# Altium schematic sheet ADDITIONAL.SchDoc
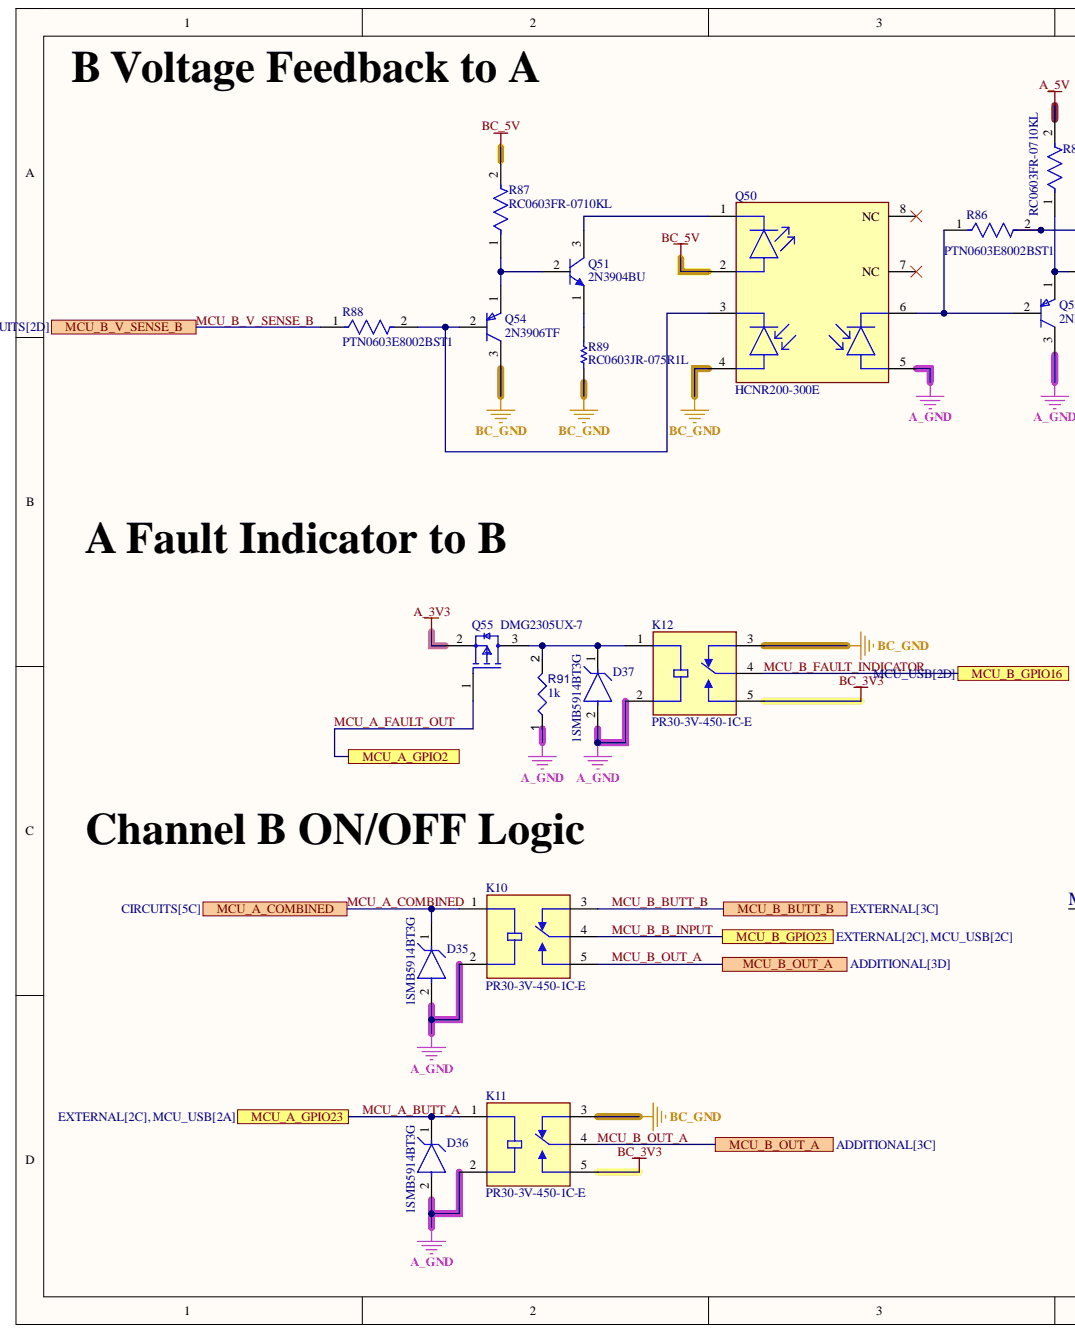
[diagram p0: ADDITIONAL.SchDoc, left]
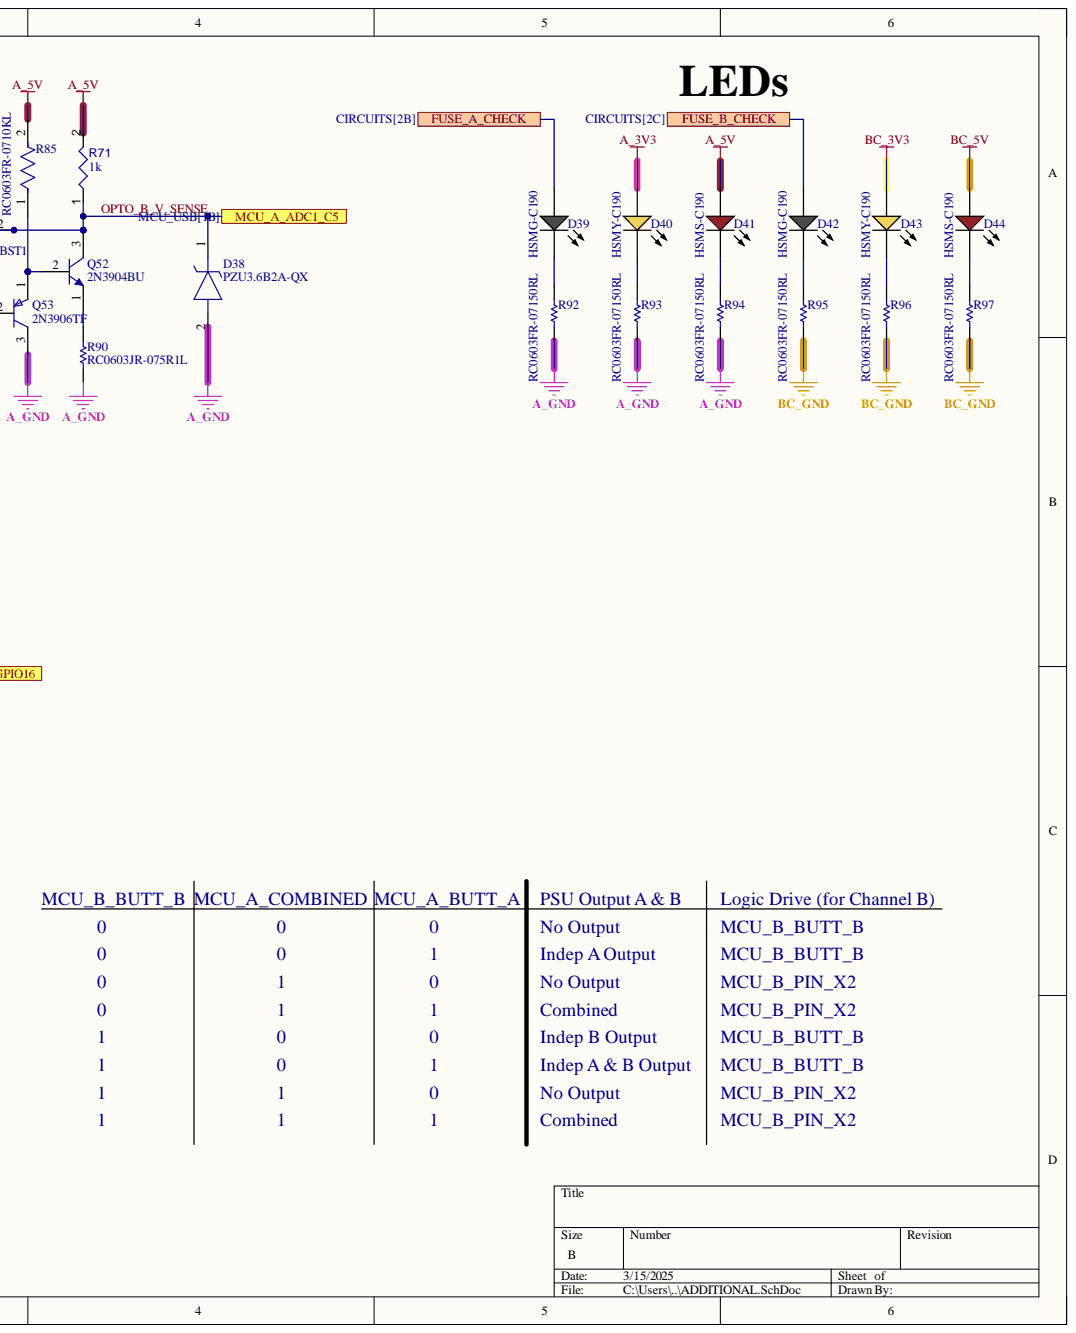
[diagram p1: ADDITIONAL.SchDoc, right]

## Parts
D35  1SMB5914BT3G  [FP-403A-03-MFG]
D36  1SMB5914BT3G  [FP-403A-03-MFG]
D37  1SMB5914BT3G  [FP-403A-03-MFG]
D38  PZU3.6B2A-QX  [PZU36B2AQX]
D39  HSMG-C190  [AVAG-HSMX-C190_V]
D40  HSMY-C190  [AVAG-HSMX-C190_V]
D41  HSMS-C190  [AVAG-HSMX-C190_V]
D42  HSMG-C190  [AVAG-HSMX-C190_V]
D43  HSMY-C190  [AVAG-HSMX-C190_V]
D44  HSMS-C190  [AVAG-HSMX-C190_V]
K10  PR30-3V-450-1C-E  [RELAY_PR30-3V-450-1C-E]
K11  PR30-3V-450-1C-E  [RELAY_PR30-3V-450-1C-E]
K12  PR30-3V-450-1C-E  [RELAY_PR30-3V-450-1C-E]
Q50  HCNR200-300E  [FP-HCNR200-300E-MFG]
Q51  2N3904BU  [FAIR-TO-92]
Q52  2N3904BU  [FAIR-TO-92]
Q53  2N3906TF  [FP-135AR-MFG]
Q54  2N3906TF  [FP-135AR-MFG]
Q55  DMG2305UX-7  [FP-SOT23-IPC_B]
R71  value 1k  [RESC1608X55X25LL10T15]  (hidden comment: Resistor 1k +/-1% 0603 100 mW)
R85  RC0603FR-0710KL  [FP-RC0603-0_55-IPC_C]
R86  PTN0603E8002BST1  [FP-PTN0603-MFG]
R87  RC0603FR-0710KL  [FP-RC0603-0_55-IPC_C]
R88  PTN0603E8002BST1  [FP-PTN0603-MFG]
R89  RC0603JR-075R1L  [RESC1608X55X25LL10T15]
R90  RC0603JR-075R1L  [RESC1608X55X25ML10T15]
R91  value 1k  [RESC1608X55X25LL10T15]  (hidden comment: Resistor 1k +/-1% 0603 100 mW)
R92  RC0603FR-07150RL  [RESC1608X55X25LL10T15]
R93  RC0603FR-07150RL  [RESC1608X55X25LL10T15]
R94  RC0603FR-07150RL  [RESC1608X55X25LL10T15]
R95  RC0603FR-07150RL  [RESC1608X55X25LL10T15]
R96  RC0603FR-07150RL  [RESC1608X55X25LL10T15]
R97  RC0603FR-07150RL  [RESC1608X55X25LL10T15]

## Ports
FUSE_A_CHECK, FUSE_B_CHECK, MCU_A_ADC1_C5, MCU_A_COMBINED, MCU_A_GPIO2, MCU_A_GPIO23, MCU_B_BUTT_B, MCU_B_GPIO16, MCU_B_GPIO23, MCU_B_OUT_A, MCU_B_V_SENSE_B

## Nets
A_3V3: D40.1 Q55.2
A_5V: D41.1 R71.2 R85.2
A_GND: D35.2 D36.2 D37.2 D38.2 K10.2 K11.2 K12.2 Q50.5 Q53.3 R90.1 R91.1 R92.1 R93.1 R94.1
BC_3V3: D43.1 K11.5 K12.5
BC_5V: D44.1 Q50.2 R87.2
BC_GND: K11.3 K12.3 Q50.4 Q54.3 R89.1 R95.1 R96.1 R97.1
FUSE_A_CHECK: D39.1 ; port FUSE_A_CHECK
FUSE_B_CHECK: D42.1 ; port FUSE_B_CHECK
MCU_A_BUTT_A: D36.1 K11.1 ; port MCU_A_GPIO23
MCU_A_COMBINED: D35.1 K10.1 ; port MCU_A_COMBINED
MCU_A_FAULT_OUT: Q55.1 ; port MCU_A_GPIO2
MCU_B_B_INPUT: K10.4 ; port MCU_B_GPIO23
MCU_B_BUTT_B: K10.3 ; port MCU_B_BUTT_B
MCU_B_FAULT_INDICATOR: K12.4 ; port MCU_B_GPIO16
MCU_B_OUT_A: K10.5 K11.4 ; port MCU_B_OUT_A
MCU_B_V_SENSE_B: R88.1 ; port MCU_B_V_SENSE_B
OPTO_B_V_SENSE: D38.1 Q52.3 R71.1 R86.2 ; port MCU_A_ADC1_C5
NetD37_1: D37.1 K12.1 Q55.3 R91.2
NetD39_2: D39.2 R92.2
NetD40_2: D40.2 R93.2
NetD41_2: D41.2 R94.2
NetD42_2: D42.2 R95.2
NetD43_2: D43.2 R96.2
NetD44_2: D44.2 R97.2
NetQ50_1: Q50.1 Q51.3
NetQ50_3: Q50.3 Q54.2 R88.2
NetQ50_6: Q50.6 Q53.2 R86.1
NetQ51_1: Q51.1 R89.2
NetQ51_2: Q51.2 Q54.1 R87.1
NetQ52_1: Q52.1 R90.2
NetQ52_2: Q52.2 Q53.1 R85.1

Not connected: Q50 7(NC) 8(NC)

## Library data of the parts
D35-D37 = CMP-1060-00194-2: DIODE ZENER 3.6V 3W SMB; Element Configuration: Single; ESD Protection: Yes; Forward Voltage: 1.5V; Impedance: 9R; Material: Plastic; Max Reverse Leakage Current: 75uA; Power Dissipation: 550mW; Test Current: 104.2mA; Tolerance: 5%; Zener Current: 416mA; Case/Package: SMB; Component Kind: Standard; Contact Plating: Tin; Halogen Free: Halogen Free; Height: 0.09inch; Lead Free: Lead Free; Length: 0.181inch; Library Name: Altium Content Vault; Max Operating Temperature: 150°C; Max Power Dissipation: 3W; Min Operating Temperature: -65°C; Number of Pins: 2; Package Quantity: 1; Packaging: Tape and Reel; Peak Reverse Current: 75uA; Power Rating: 3W; Radiation Hardening: No; REACH SVHC: No SVHC; Voltage Rating (DC): 3.6V; Voltage Tolerance: 5%; Width: 0.156inch; Working Voltage: 3.6V; Zener Voltage: 3.6V
D38 = CMP-078-000001-3: vp_PZUXBA-Q_SER - Single Zener diodes@en-us,Non-repetitive peak reverse power dissipation: PZSM  40 W,Total power dissipation: Ptot  320 mW,B: approximately +/-5 %,B1; Manufacturer_Name: Nexperia; Component Kind: Standard; Datasheet Link: https://assets.nexperia.com/documents/data-sheet/PZUXBA-Q_SER.pdf; Design Item ID: PZU3.6B2A-QX; Height: 1.1mm; Manufacturer_Part_Number: PZU3.6B2A-QX; Source: SamacSys.SchLib; Library Name: PCB Lab - Cooper Union
D39 D42 = CMP-1744-00002-1: Chip LED, Green, 2.2 V, -40 to 85 degC, 2-Pin SMD, RoHS, Tape and Reel; Access Time: 3500 ns; Case\Package: SOIC; Clock Frequency: 100 KHz; Density: 32 kb; Frequency: 400 KHz; Interface: Serial; Max Supply Voltage: 5.5 V; Min Supply Voltage: 1.7 V; Nominal Supply Current: 2 mA; Component Kind: Standard; ComponentLink1Description: Manufacturer URL; ComponentLink1URL: http://www.avagotech.com/; ComponentLink2Description: Datasheet; ComponentLink2URL: http://docs.avagotech.com/docs/AV02-0551EN; ComponentLink3Description: Package Specification; ComponentLink3URL: http://www.fairchildsemi.com/dwg/MA/MA03D.pdf; DatasheetVersion: Mar-12; Height: 1.5 mm; Length: 5 mm; Library Name: Altium Content Vault; Max Clock Frequency: 100 KHz; Max Operating Temperature: 85 degC; Min Operating Temperature: -40 degC; Mount: Surface Mount; Package Quantity: 3000; PackageDescription: 2-Pin Surface Mount Device, Body 1.6 x 0.8 mm, Height 0.9 mm; PackageReference: HSMX-C190; Packaging: Tape and Reel; Pins: 8; Radiation Hardening: No; REACH SVHC: No SVHC; RoHSCompliant: true; Width: 4 mm
D40 D43 = CMP-1744-00003-1: LED Uni-Color Yellow, 65 mW, 20 mA, -40 to 85 degC, 2-Pin SMD, RoHS, Tape and Reel; Case\Package: 0603; Power Rating: 100 mW; Resistance: 390 Ohm; Tolerance: 1%; Voltage Rating: 50 V; Case Code (Imperial): 0603; Case Code (Metric): 1608; Component Kind: Standard; ComponentLink1Description: Manufacturer URL; ComponentLink1URL: http://www.avagotech.com/; ComponentLink2Description: Datasheet; ComponentLink2URL: http://docs.avagotech.com/docs/AV02-0551EN; ComponentLink3Description: Package Specification; ComponentLink3URL: http://www.fairchildsemi.com/dwg/MA/MA03D.pdf; DatasheetVersion: Mar-12; Height: 0.45 mm; Length: 1.6 mm; Library Name: Altium Content Vault; Max Operating Temperature: 155 degC; Min Operating Temperature: -55 degC; Mount: Surface Mount; Package Quantity: 5000; PackageDescription: 2-Pin Surface Mount Device, Body 1.6 x 0.8 mm, Height 0.9 mm; PackageReference: HSMX-C190; Packaging: Tape and Reel; Pins: 2; REACH SVHC: No SVHC; RoHSCompliant: true; Voltage Rating (DC): 50 V; Width: 0.8 mm
D41 D44 = CMP-2000-06427-1: LED Uni-Color Red, 52 mW, 20 mA, -40 to 85 degC, 2-Pin SMD, RoHS, Tape and Reel; Manufacturer: Avago; Chip Material: GaP; DELETED: Compliant; Dominant Wave Length: 626nm; Forward Current: 25mA; Forward Voltage: 2.6V; High Brightness: No; Lens Type: ColorlessDiffused; Lum Intensity: 10mcd; Main Category: ChipLED; Number of  Elements: 1; Peak Wavelength: 630nm; Power Dissipation: 52W; Product Depth (mm): 0.8mm; Product Length (mm): 1.6mm; Reverse Voltage: 5V; Shape Type: Rectangular; Test Current (It): 20mA; Viewing Angle: 170deg; Component Kind: Standard; Datasheet URL: http://docs.avagotech.com/docs/AV02-0551EN; Datasheet Version: 03/2012; Emitting Color: Red; Lens Dimensions: 1x0.8x0.5mm; Library Name: Altium Content Vault; Luminous Intensity: 10mcd; Manufacturer URL: http://www.avagotech.com/; Mounting: SurfaceMount; Mounting Technology: SurfaceMount; Operating Temp Range: -40Cto85C; Operating Temperature Classification: Industrial; Package Description: 2-Pin Surface Mount Device, Body 1.6 x 0.8 mm, Height 0.9 mm; Package Reference: HSMX-C190; Package Type: ChipLed; Packaging: TapeandReel; Pin Count: 2; Product Height (mm): 0.8mm; Rad Hardened: No; Type: Uni-Color
K10-K12 = CMP-036-000000-2: SPDT 3VDC Coil Voltage, 10A 400VAC/150VDC Contact Rating 20.5 x 10.2 x 15.3 mm, 3 V, 10 A, SPDT ( 1 Form C), Epoxy Sealed, Power Relay; MF: Same Sky; Check_prices: https://www.snapeda.com/parts/PR30-3V-450-1C-E/Same+Sky/view-part/?ref=eda; Component Kind: Standard; Design Item ID: PR30-3V-450-1C-E; MP: PR30-3V-450-1C-E; Package: None; SnapEDA_Link: https://www.snapeda.com/parts/PR30-3V-450-1C-E/Same+Sky/view-part/?ref=snap; Source: PR30-3V-450-1C-E.SchLib; Library Name: PCB Lab - Cooper Union
Q50 = CMP-2000-06389-3: OPTOISO 5KV LINEAR PHVOLT 8DIPGW; Approvals: UL; Current Transfer Ratio: 0.75%; Forward Current: 25mA; Forward Voltage: 1.6V; Input Current: 20mA; Input Type: DC; Max Power Dissipation: 60mW; Number of Channels: 1; Reverse Breakdown Voltage: 2.5V; Case/Package: SMD/SMT; Component Kind: Standard; Contact Plating: Tin; Isolation Voltage: 5kV; Lead Free: Lead Free; Library Name: Altium Content Vault; Max Input Current: 25mA; Max Operating Temperature: 85°C; Min Operating Temperature: -55°C; Mount: Surface Mount; Number of Circuits: 1; Number of Elements: 1; Number of Pins: 8; Package Quantity: 42; Packaging: Rail/Tube; Power Dissipation: 60mW; Radiation Hardening: No; REACH SVHC: No SVHC; Reverse Voltage (DC): 2.5V; RoHS Compliant: Yes; Series: -
Q51 Q52 = CMP-2000-04944-1: NPN General-Purpose Amplifier, 40 V VCEO, 0.2 A, -55 to 150 degC, 2-Pin TO-92, RoHS, Bulk; Manufacturer: FairchildSemiconductor; Maximum Collector Base Voltage: 60V; Maximum Collector Emitter Saturation Voltage: 0.2@1mA@10mA; Maximum Collector Emitter Voltage: 40V; Maximum DC Collector Current: 0.2A; Maximum Operating Frequency: 300(Min)MHz; Maximum Power Dissipation: 625mW; Minimum DC Current Gain: 40@0.1mA@1V; Rad Hard: No; Category: GPBJT; Component Kind: Standard; Datasheet URL: https://datasheet.ciiva.com/4722/2n3904-82270-4722369.pdf; Datasheet Version: Rev. 1.1.0, 10/2014; Library Name: Altium Content Vault; Manufacturer URL: https://www.fairchildsemi.com/; Mounting: ThroughHole; Operating Temperature: -55to150degC; Package: 3TO-92; Package Description: 3-Pin Transistor Outline Package, Body Dia 4.79 mm, Pitch 1.27 mm; Package Reference: TO-92; Packaging: Bulk; Pin Count: 3; Type: NPN
Q53 Q54 = CMP-00278-84408866-1: 2N3906TF Small Signal Bipolar Transistor, 0.2A I(C), 40V V(BR)CEO, 1-Element, PNP, Silicon, TO-92; Manufacturer Lifecycle Status: ACTIVE; Collector Base Voltage (VCBO): -40V; Collector Emitter Saturation Voltage: -400mV; Current Rating: -200mA; Element Configuration: Single; Emitter Base Voltage (VEBO): -5V; Frequency: 250MHz; hFE Min: 100; Max Breakdown Voltage: 160V; Max Junction Temperature (Tj): 150°C; Max Power Dissipation: 625mW; Number of Elements: 1; Case/Package: TO-92; Collector Emitter Breakdown Voltage: -40V; Collector Emitter Voltage (VCEO): -40V; Component Kind: Standard; Gain Bandwidth Product: 250MHz; Height: 5.33mm; Lead Free: Lead Free; Length: 5.2mm; Library Name: Altium Content Vault; Lifecycle Status: Production; Max Collector Current: -200mA; Max Frequency: 250MHz; Max Operating Temperature: 150°C; Min Operating Temperature: -55°C; Mount: Through Hole; NexarPartId: 84408866; Number of Pins: 3; Packaging: Tape and Reel; Polarity: PNP; Power Dissipation: 625mW; Radiation Hardening: No; REACH SVHC: No SVHC; RoHS: Compliant; Schedule B: 8541210080; Transition Frequency: 250MHz; Voltage Rating (DC): -40V; Weight: 240mg; Width: 4.19mm
Q55 = CMP-1693-00002-4: MOSFET P-CH 20V 4.2A SOT23; Drain to Source Resistance: 200mR; Element Configuration: Single; Fall Time: 34.7ns; Gate to Source Voltage (Vgs): 8V; Input Capacitance: 808pF; Max Power Dissipation: 1.4W; Number of Channels: 1; On-State Resistance: 52MR; Rise Time: 13.7ns; Threshold Voltage: -900mV; Turn-Off Delay Time: 79.3ns; Turn-On Delay Time: 10.8ns; Case/Package: SOT-23; Component Kind: Standard; Contact Plating: Tin; Continuous Drain Current (ID): 4.2A; Drain to Source Voltage (Vdss): 20V; Height: 1.1mm; Lead Free: Lead Free; Length: 3mm; Library Name: Altium Content Vault; Max Operating Temperature: 150°C; Min Operating Temperature: -55°C; Mount: Surface Mount; Number of Pins: 3; Package Quantity: 3000; Packaging: Tape and Reel; Radiation Hardening: No; Rds On Max: 52mR; REACH SVHC: No SVHC; Weight: 0.000282oz; Width: 1.4mm
R71 R91 = CMP-009-00014-5: Chip Resistor, 1 KOhm, +/-1%, 0.1 W, -55 to 155 degC, 0603 (1608 Metric); Power: 100mW; Tolerance: 1%; Voltage Rating: 50V; Case/Package: 0603; Component Kind: Standard; Max Operating Temperature: 155°C; Min Operating Temperature: -55°C; Mounting Technology: SM; Pins: 2; RoHS Compliant: True; Library Name: PCB Lab - Cooper Union
R85 R87 = CMP-2100-03664-2: RES 10K OHM 1% 1/10W 0603; Max Power Dissipation: 100mW; Resistance: 10kR; Temperature Coefficient: 100ppm/°C; Voltage Rating: 75V; Voltage Rating (DC): 50V; Case Code (Imperial): 0603; Case Code (Metric): 1608; Case/Package: 0603; Component Kind: Standard; Construction: Rectangular; Depth: 0.8mm; Features: Moisture Resistant; Height: 0.45mm; Lead Free: Lead Free; Lead Pitch: 0.3mm; Length: 1.6mm; Library Name: Altium Content Vault; Max Operating Temperature: 155°C; Military Standard: Not; Min Operating Temperature: -55°C; Number of Pins: 2; Number of Terminations: 2; Packaging: Tape and Reel; Power Rating: 100mW; Resistance Tolerance: 1%; Resistor Type: Thick Film; RoHS Compliant: Yes; Series: RC; Size Code: 0603; Termination: SMD/SMT; Tolerance: 1%; Weight: 7.1E-05oz; Width: 0.8mm
R86 R88 = CMP-02407-000009-1: RES 80K OHM 0.1% 0.15W 0603; Manufacturer: Vishay; Power Rating: 150mW; Resistance: 80kΩ; Temperature Coefficient: 25ppm/°C; Value: 80kΩ; Voltage Rating: 75V; Automotive: No; Category: Res; Component Kind: Standard; ComponentLink2Description: Datasheet; ComponentLink2URL: https://www.vishay.com/docs/60026/ptn.pdf; Composition: Thin Film; Device Class L1: Passive Components; Device Class L2: Resistors; Device Class L3: Chip SMD Resistors; Digikey Description: RES 80K OHM 0.1% 0.15W 0603; Footprint Url: https://www.vishay.com/docs/60119/landpatterns.pdf; Height: 0.02inch; Ipc Land Pattern Name: RESC16381X51; Lead Free: Yes; Library Name: Altium Content Vault; Manufacturer Part Number: PTN0603E8002BST1; Octopart: https://octopart.com/ptn0603e8002bst1-vishay-44224719; Package: PTN0603; RoHS: TRUE; Series: PTN; Temperature: -55°C to +155°C; Temperature Range High: +155°C; Temperature Range Low: -55°C; Tolerance: 0.1%
R89 R90 = CMP-2000-04283-1: Manufacturer: Yageo; Component Kind: Standard; Library Name: Altium Content Vault; Part Number: RC0603JR-075R1L
R92-R97 = CMP-1659-00057-1: Chip Resistor, 150 Ohm, +/- 1%, 0.1 W, -55 to 155 degC, 0603 (1608 Metric), RoHS, Tape and Reel; Case\Package: Radial; Forward Voltage: 2.2 V; Illumination Colour: Green; Lens Style: Clear; Luminous Intensity: 200 mcd; Viewing Angle: 20 degrees; Colour: Green; Component Kind: Standard; ComponentLink1Description: Manufacturer URL; ComponentLink1URL: http://www.yageo.com/; ComponentLink2Description: Datasheet; ComponentLink2URL: http://www.yageo.com/documents/recent/PYu-RC_Group_51_RoHS_L_04.pdf; DatasheetVersion: Rev. 4, 02/2016; Height: 8.6 mm; Library Name: Altium Content Vault; Mount: Through Hole; Nominal Vf: 2.2 V; Package Quantity: 1000; PackageDescription: 2-Pin Surface Mount Device, Body 1.6 x 0.8 mm; PackageReference: 0603; Packaging: Bulk; RoHSCompliant: true
